# Altium schematic sheet GPS_WS_NEO7M.SchDoc(GPS_WS_NEO7M)
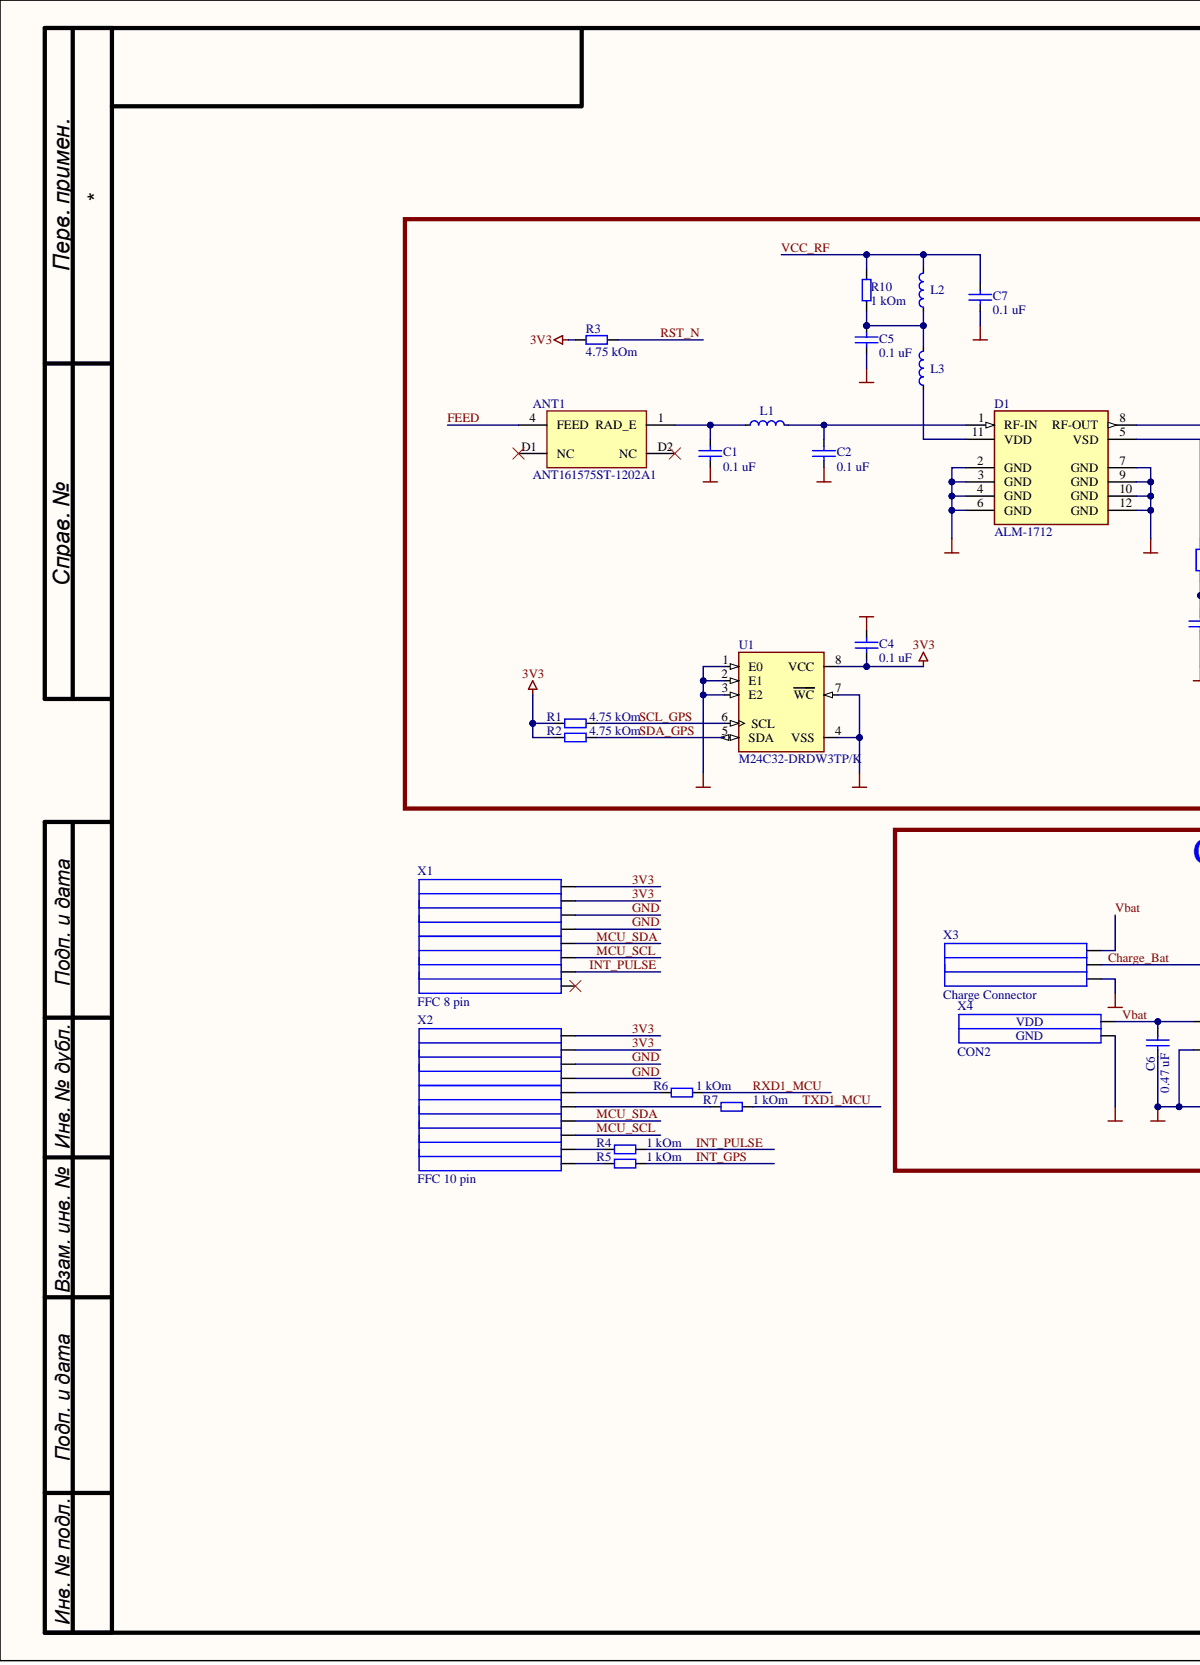
[diagram p0: GPS_WS_NEO7M.SchDoc, left]
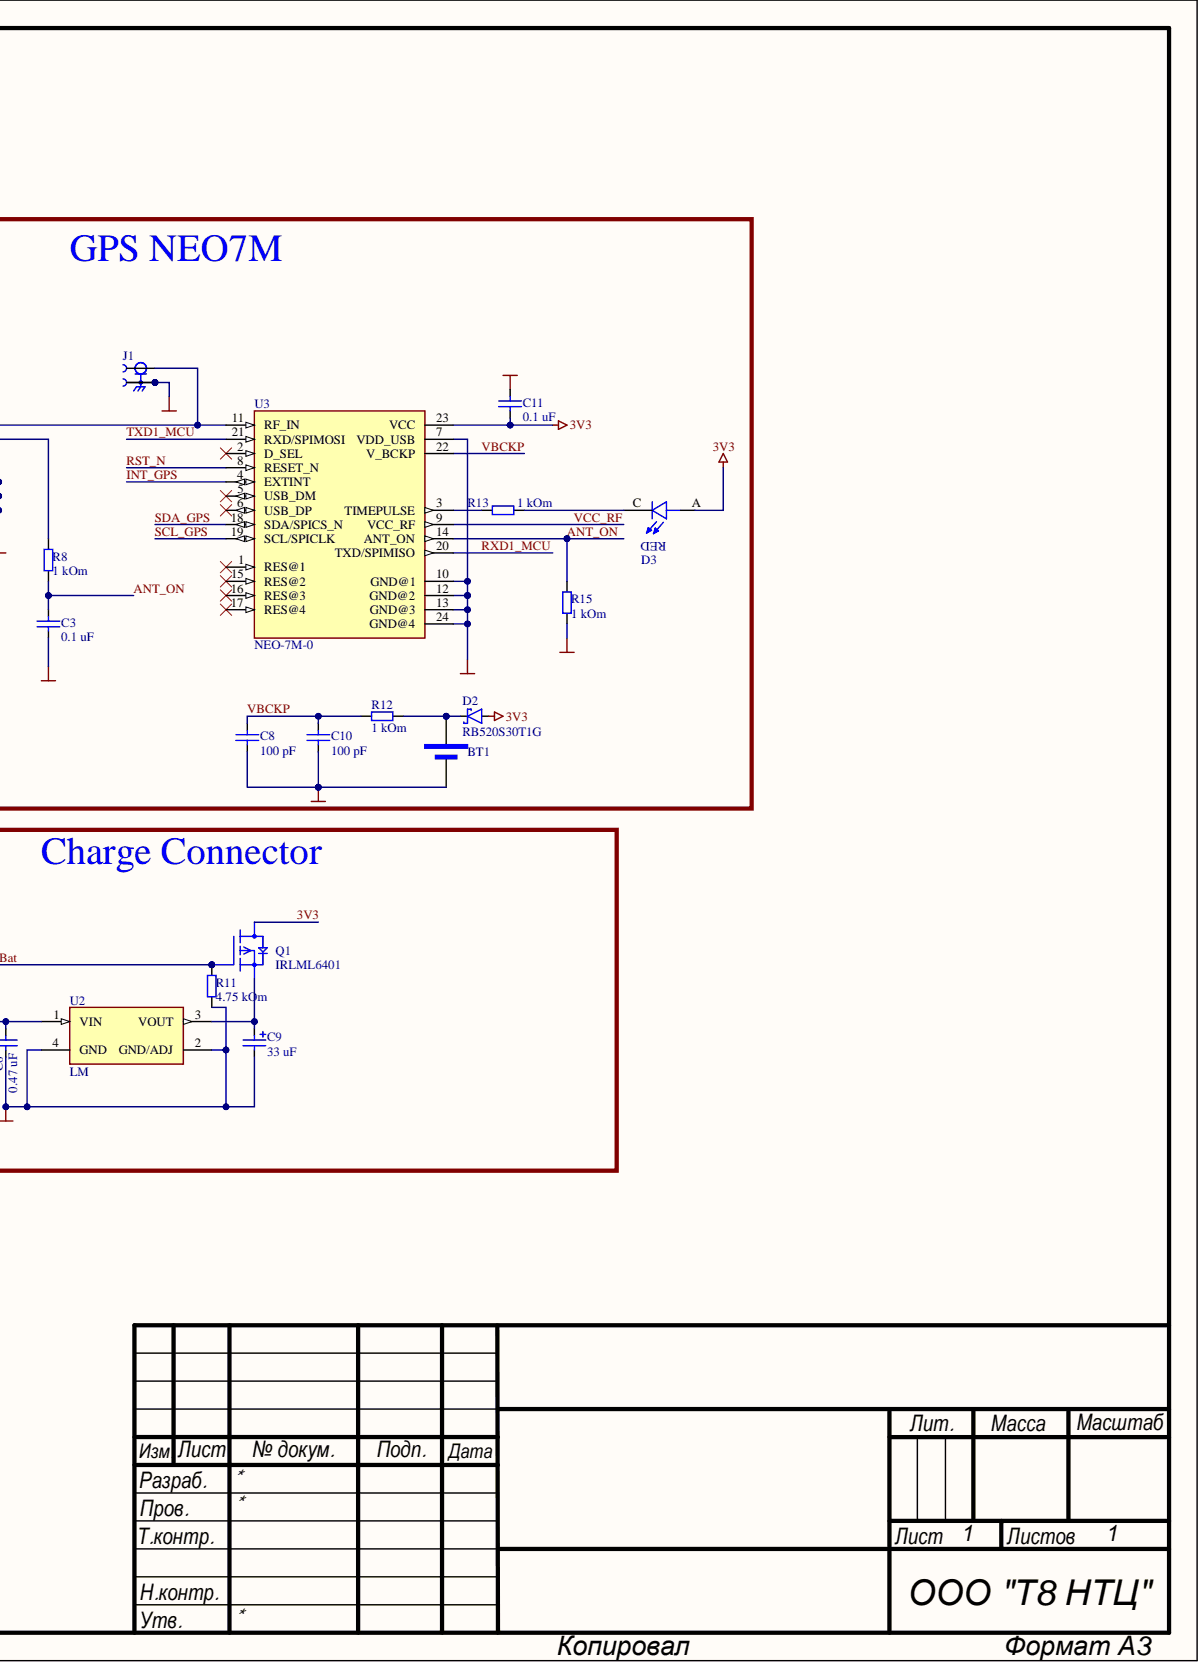
[diagram p1: GPS_WS_NEO7M.SchDoc, right]

## Parts
ANT1  ANT161575ST-1202A1  [ANT161575ST1202A1]
BT1  ML414H_IV01E  [SEIKO_ML414H_IV01E]  (value not drawn)
C1  value 0.1 uF  [CAPC1005X55N]  (hidden comment: STD_CAP)
C2  value 0.1 uF  [CAPC1005X55N]  (hidden comment: STD_CAP)
C3  value 0.1 uF  [CAPC1005X55N]  (hidden comment: STD_CAP)
C4  value 0.1 uF  [CAPC1005X55N]  (hidden comment: STD_CAP)
C5  value 0.1 uF  [CAPC1005X55N]  (hidden comment: STD_CAP)
C6  value 0.47 uF  [CAPC1608X90N]  (hidden comment: STD_CAP)
C7  value 0.1 uF  [CAPC1608X90N]  (hidden comment: STD_CAP)
C8  value 100 pF  [CAPC1005X55N]  (hidden comment: STD_CAP)
C9  value 33 uF  [CAPMP3216X180N]  (hidden comment: STD_CAP_POL)
C10  value 100 pF  [CAPC1005X55N]  (hidden comment: STD_CAP)
C11  value 0.1 uF  [CAPC1005X55N]  (hidden comment: STD_CAP)
D1  ALM-1712  [ALM-1712]
D2  RB520S30T1G  [SOD523]
D3  7012X1  [LED_7012X1]  (value not drawn)
J1  CONUFL001-SMD  [LINX_CONUFL001-SMD]  (value not drawn)
L1  STD_IND  [RESC1005X40N]  (value not drawn)
L2  STD_IND  [RESC1005X40N]  (value not drawn)
L3  STD_IND  [RESC1005X40N]  (value not drawn)
Q1  IRLML6401  [SOT23]
R1  value 4.75 kOm  [RESC1005X40N]  (hidden comment: 2904)
R2  value 4.75 kOm  [RESC1005X40N]  (hidden comment: 2904)
R3  value 4.75 kOm  [RESC1005X40N]  (hidden comment: 2904)
R4  value 1 kOm  [RESC1005X40N]  (hidden comment: 2839)
R5  value 1 kOm  [RESC1005X40N]  (hidden comment: 2839)
R6  value 1 kOm  [RESC1005X40N]  (hidden comment: 2839)
R7  value 1 kOm  [RESC1005X40N]  (hidden comment: 2839)
R8  value 1 kOm  [RESC1005X40N]  (hidden comment: 2839)
R10  value 1 kOm  [RESC1005X40N]  (hidden comment: 2839)
R11  value 4.75 kOm  [RESC1005X40N]  (hidden comment: 2904)
R12  value 1 kOm  [RESC1005X40N]  (hidden comment: 2839)
R13  value 1 kOm  [RESC1005X40N]  (hidden comment: 2839)
R15  value 1 kOm  [RESC1005X40N]  (hidden comment: 2839)
U1  M24C32-DRDW3TP/K  [SOP65P640X120-8N]
U2  LM  [SOT229P700X180-4N]
U3  NEO-7M-0  [XCVR_NEO-7M-0]
X1  FFC 8 pin  [Charge Connector]
X2  FFC 10 pin  [SAMTEC_ZF5S-10-01-T-WT]
X3  Charge Connector  [POGO PINS]
X4  CON2  [CON2]

## Nets
3V3: C4.2 C11.2 D2.2 D3.A Q1.3 R1.1 R2.1 R3.2 U1.8(VCC) U3.23(VCC) X1.1 X1.2 X2.1 X2.2
ANT_ON: C3.1 R8.2 R15.1 U3.14(ANT_ON)
Charge_Bat: Q1.1 R11.2 X3.2
FEED: ANT1.4(FEED)
GND: BT1.2 C1.2 C2.2 C3.2 C4.1 C5.2 C6.2 C7.2 C8.2 C9.2 C10.2 C11.1 D1.2(GND) D1.3(GND) D1.4(GND) D1.6(GND) D1.7(GND) D1.9(GND) D1.10(GND) D1.12(GND) J1.2 J1.3 R11.1 R15.2 U1.1(E0) U1.2(E1) U1.3(E2) U1.4(VSS) U1.7(WC) U2.2(GND/ADJ) U2.4(GND) U3.7(VDD_USB) U3.10(GND@1) U3.12(GND@2) U3.13(GND@3) U3.24(GND@4) X1.3 X1.4 X2.3 X2.4 X3.3 X4.2
INT_GPS: R5.2 U3.4(EXTINT)
INT_PULSE: R4.2 X1.7
MCU_SCL: X1.6 X2.8
MCU_SDA: X1.5 X2.7
RST_N: R3.1 U3.8(RESET_N)
RXD1_MCU: R6.1 U3.20(TXD/SPIMISO)
SCL_GPS: R1.2 U1.6(SCL) U3.19(SCL/SPICLK)
SDA_GPS: R2.2 U1.5(SDA) U3.18(SDA/SPICS_N)
TXD1_MCU: R7.2 U3.21(RXD/SPIMOSI)
Vbat: C6.1 U2.1(VIN) X3.1 X4.1
VBCKP: C8.1 C10.1 R12.2 U3.22(V_BCKP)
VCC_RF: C7.1 L2.2 R10.1 U3.9(VCC_RF)
NetANT1_1: ANT1.1(RAD_E) C1.1 L1.1
NetBT1_1: BT1.1 D2.1 R12.1
NetC2_1: C2.1 D1.1(RF-IN) L1.2
NetC5_1: C5.1 L2.1 L3.2 R10.2
NetC9_1: C9.1 Q1.2 U2.3(VOUT)
NetD1_5: D1.5(VSD) R8.1
NetD1_8: D1.8(RF-OUT) J1.1 U3.11(RF_IN)
NetD1_11: D1.11(VDD) L3.1
NetD3_C: D3.C R13.1
NetR4_1: R4.1 X2.9
NetR5_1: R5.1 X2.10
NetR6_2: R6.2 X2.5
NetR7_1: R7.1 X2.6
NetR13_2: R13.2 U3.3(TIMEPULSE)

Not connected: ANT1 D1(NC) D2(NC); U3 1(RES@1) 2(D_SEL) 5(USB_DM) 6(USB_DP) 15(RES@2) 16(RES@3) 17(RES@4); X1 8

## Library data of the parts
ANT1 = ANT161575ST-1202A1: Manufacturer: AMOTECH; ManufacturerPartnumber: AMAN1003030ST01; BOM: GPS Antenna    AMAN1003030ST01 AMOTECH; Human_Readable: GPS Antenna; Component Kind: Standard; Footprint_Description: Custom; Library Name: SCH_Wearing_sensor.SchLib
BT1 = ML414H_IV01E: Coin, 4.8mm 3 V Lithium Battery Rechargeable (Secondary) 1mAh; Manufacturer: Seiko Instruments; ManufacturerPartnumber: ML414H IV01E; BOM: Перезаряжаемая батарейка    ML414H IV01E Seiko Instruments; Human_Readable: Перезаряжаемая батарейка; Availability: In Stock; Check_prices: https://www.snapeda.com/parts/ML414H%20IV01E/Seiko+Instruments/view-part/?ref=eda; Component Kind: Standard; Footprint_Description: SOT-89 Seiko; MF: Seiko Instruments; MP: ML414H IV01E; Package: SOT-89 Seiko; Price: None; Purchase-URL: https://pricing.snapeda.com/search/part/ML414H IV01E/?ref=eda; Library Name: SCH_Wearing_sensor.SchLib
C1-C5 C11 = 5571: Manufacturer: muRata; ManufacturerPartnumber: GRM155R71H104KE14D; AuthorCreation_Comp: altium_lib_sch_p; AuthorModification_Comp: anosova; BOM: Конденсатор 0402 0.1 uF ±10% 50V X7R    GRM155R71H104KE14D muRata; Comp_Class_Name: Capacitors Chip SMD 0402; Comp_Class_Number: 200003; Comp_Description: Capacitors 0402 0.10 uF ±10% 50V X7R; Comp_ID: 200003-430; Database Table Name: Comp_All; Dielectric: X7R; Human_Readable: Конденсатор 0402 0.1 uF ±10% 50V X7R; Lib_Description: Capacitor; Precision: 10%; Temp_Range: -55°C to +125°C; Voltage_Range: 50V; Component Kind: Standard; ComponentLink1Description: MANUFACTURER_URL; ComponentLink1URL: https://www.murata.com/~/media/webrenewal/support/library/catalog/products/capacitor/mlcc/c02e.ashx; Footprint_Description: Chip Capacitor EIA 0402. METRIC 1005, 2-Leads, Body 1,00x0,5mm, IPC Medium Density; HelpURL: \\corp1\RNDlibrary\_Library\Altium_lib\Datasheet\muRata\Chip_Monolithic_Ceramic_Capacitors\c02e.pdf; Library Name: Altium_lib.SVNDbLib; Number_Base: 5571; TimeCreation_Comp: 05.04.2018 11:21:57; TimeModification_Comp: 18.11.2021 13:11:41; Verified: N
C6 = 3938: Manufacturer: muRata; ManufacturerPartnumber: GRM188R71A474KA61D; BOM: Конденсатор 0603 0.47 uF ±10% 10V X7R    GRM188R71A474KA61D muRata; Comp_Class_Name: Capacitors Chip SMD 0603; Comp_Class_Number: 200004; Comp_Description: Capacitors 0603 0.47 uF ±10% 10V X7R; Comp_ID: 200004-0111; Database Table Name: Comp_All; Dielectric: X7R; Human_Readable: Конденсатор 0603 0.47 uF ±10% 10V X7R; Lib_Description: Capacitor; Precision: ±10%; Temp_Range: -55 to 125°C; Voltage_Range: 10V; Component Kind: Standard; ComponentLink1Description: MANUFACTURER_URL; ComponentLink1URL: http://www.murata.com/products/catalog/pdf/c02e.pdf; Footprint_Description: Chip Capacitor EIA 0603. METRIC 1608, 2-Leads, Body 1,6x0,8mm, IPC Medium Density; HelpURL: \\corp1\RNDlibrary\_Library\Altium_lib\Datasheet\muRata\Chip_Monolithic_Ceramic_Capacitors\Chip_Monolithic_Ceramic_Capacitors.pdf; Library Name: Altium_lib.SVNDbLib; Number_Base: 3938; TimeModification_Comp: 06.12.2011 14:46:17
C7 = 6202: Manufacturer: TDK; ManufacturerPartnumber: C1608X7R1H104K; AuthorCreation_Comp: anosova; BOM: Capacitors 0603 0.1 uF ±10% 50V X7R    C1608X7R1H104K TDK; Comp_Class_Name: Capacitors Chip SMD 0603; Comp_Class_Number: 200004; Comp_Description: Capacitors 0603 0.1 uF ±10% 50V X7R; Comp_ID: 200004-122; Database Table Name: Comp_All; Dielectric: X7R; Lib_Description: Capacitor; Precision: ± 10%; Temp_Range: -55°C to +125°C; Voltage_Range: 50 V; Component Kind: Standard; ComponentLink1Description: MANUFACTURER_URL; ComponentLink1URL: https://media.digikey.com/pdf/Data%20Sheets/TDK%20PDFs/C_Series_GeneralAppl_B11.pdf; Footprint_Description: Chip Capacitor EIA 0603. METRIC 1608, 2-Leads, Body 1,60x0,8mm, IPC Medium Density; HelpURL: \\corp1\RNDlibrary\_Library\Altium_lib\Datasheet\TDK\Ceramic_Capacitors_C-Series\cseries.pdf; Human_Readable: Capacitors 0603 0.1 uF ±10% 50V X7R; Library Name: Altium_lib.SVNDbLib; Number_Base: 6202; TimeCreation_Comp: 29.10.2021 11:15:01; TimeModification_Comp: 29.10.2021 11:29:43; Verified: N; AuthorModification_Comp: anosova
C8 C10 = 3764: Manufacturer: muRata; ManufacturerPartnumber: GRM1555C1H101JA01D; AuthorModification_Comp: anosova; BOM: Конденсатор 0402 100 pF ±5% 50V  C0G (NP0)    GRM1555C1H101JA01D muRata; Comp_Class_Name: Capacitors Chip SMD 0402; Comp_Class_Number: 200003; Comp_Description: Capacitors 0402 100 pF ±5% 50V  C0G (NP0); Comp_ID: 200003-0363; Database Table Name: Comp_All; Dielectric: C0G (NP0); Human_Readable: Конденсатор 0402 100 pF ±5% 50V  C0G (NP0); Lib_Description: Capacitor; Precision: ±5%; Temp_Range: 25 to 125°C; Voltage_Range: 50V; Component Kind: Standard; ComponentLink1Description: MANUFACTURER_URL; ComponentLink1URL: http://www.murata.com/products/catalog/pdf/c02e.pdf; Footprint_Description: Chip Capacitor EIA 0402. METRIC 1005, 2-Leads, Body 1,00x0,5mm, IPC Medium Density; HelpURL: \\corp1\RNDlibrary\_Library\Altium_lib\Datasheet\muRata\Chip_Monolithic_Ceramic_Capacitors\Chip_Monolithic_Ceramic_Capacitors.pdf; Library Name: Altium_lib.SVNDbLib; Number_Base: 3764; Price: 0,0599999986588955; TimeModification_Comp: 18.11.2021 12:51:58
C9 = 4456: Manufacturer: Kemet; ManufacturerPartnumber: T491A336K010AT; BOM: Конденсатор танталовый корпус A  METRIC 3216-18   33 uF 10% 10V    T491A336K010AT Kemet; Comp_Class_Name: Capacitors Tantal; Comp_Class_Number: 2010; Comp_Description: Tantal Capacitor Case A  METRIC 3216-18   33 uF 10% 10V; Comp_ID: 2010-0363; Database Table Name: Comp_All; Human_Readable: Конденсатор танталовый корпус A  METRIC 3216-18   33 uF 10% 10V; Lib_Description: Polar Capacitor; Precision: 10%; Temp_Range: -55°C to +125°C; Voltage_Range: 10V; Component Kind: Standard; ComponentLink1Description: MANUFACTURER_URL; ComponentLink1URL: http://www.kemet.com/kemet/web/homepage/kechome.nsf/weben/9F2DE33590D9247E8525788D0059B1E1/$file/KEM_TC101_STD.pdf; Footprint_Description: Tantal Capacitor,Case A, METRIC 3216-18. 2-Leads, Body 3.2x1.6mm, IPC Medium Density; HelpURL: \\corp1\RNDlibrary\_Library\Altium_lib\Datasheet\KEMET\T491\KEM_TC101_STD.pdf; Library Name: Altium_lib.SVNDbLib; Number_Base: 4456; TimeModification_Comp: 08.12.2011 13:13:16
D1 = ALM-1712: Sot223/Pkg 1-Amp 3.3-Volt Low Drop Out Voltage Regulatator; MF: Advanced Monolithic Systems; MP: AMS1117-3.3; Availability: Not in stock; Check_prices: https://www.snapeda.com/parts/AMS1117-3.3/Advanced+Monolithic+Systems/view-part/?ref=eda; Component Kind: Standard; Package: SOT-223 Seeed Technology; Price: None; SnapEDA_Link: https://www.snapeda.com/parts/AMS1117-3.3/Advanced+Monolithic+Systems/view-part/?ref=snap; Library Name: SCH_Wearing_sensor.SchLib
D2 = RB520S30T1G: Diode Schottky 30 V 200mA (DC) Surface Mount SOD-523; Manufacturer: NXP Semiconductors; ManufacturerPartnumber: 1PS79SB70; BOM: Diode Schotky    1PS79SB70 NXP Semiconductors; Human_Readable: Diode Schotky; Component Kind: Standard; Footprint_Description: SOD523; MP: 1PS79SB70; Package: None; Price: None; Library Name: SCH_Wearing_sensor.SchLib
D3 = 7012X1: Red - LED Indication - Discrete 1.7V 0805 (2012 Metric); MF: VCC; Availability: In Stock; Check_prices: https://www.snapeda.com/parts/7012X1/Visual+Communications/view-part/?ref=eda; Component Kind: Standard; Library Name: 7012X1.SchLib; MP: 7012X1; Package: 0805 Visual Communications; Price: None; Purchase-URL: https://pricing.snapeda.com/search/part/7012X1/?ref=eda; SnapEDA_Link: https://www.snapeda.com/parts/7012X1/Visual+Communications/view-part/?ref=snap
J1 = CONUFL001-SMD: Manufacturer: TE Connectivity; ManufacturerPartnumber: 1909763-1; BOM: UMCC разъем    1909763-1 TE Connectivity; Human_Readable: UMCC разъем; Availability: In Stock; Check_prices: https://www.snapeda.com/parts/CONUFL001-SMD/Linx/view-part/5842340/?ref=eda; Component Kind: Standard; Footprint_Description: UMCC; Package: None; Price: None; SnapEDA_Link: https://www.snapeda.com/parts/CONUFL001-SMD/Linx/view-part/5842340/?ref=snap; Library Name: SCH_Wearing_sensor.SchLib
L1-L3 = 5343: Manufacturer: muRata; ManufacturerPartnumber: LQW15AN24NG00; AuthorCreation_Comp: altium_lib_sch_p; BOM: RF Inductor wire wound 0402 Size    LQW15AN24NG00 muRata; Comp_Class_Name: Inductances Chip; Comp_Class_Number: 13010000; Comp_Description: RF Inductor wire wound 0402 Size; Comp_ID: 13010000-030; Current_Range: 280 mA; Database Table Name: Comp_All; Precision: ±2%; Temp_Range: -55°C to +125°C; Value: 24 nH; Component Kind: Standard; ComponentLink1Description: MANUFACTURER_URL; HelpURL: \\Corp1\RNDlibrary\_Library\Altium_lib\Datasheet\muRata\Chip_Inductors\Chip_Inductors.o05e.pdf; Human_Readable: RF Inductor wire wound 0402 Size; Lib_Description: RF Inductor wire wound 0402 Size; Library Name: Altium_lib.SVNDbLib; Number_Base: 5343; Price: 0,239999994635582; TimeCreation_Comp: 10.06.2015 18:13:48; TimeModification_Comp: 10.06.2015 18:37:11; Verified: N; AuthorModification_Comp: altium_lib_sch_p
Q1 = IRLML6401: P-Channel 12V 4.3A (Ta) 1.3W (Ta) Surface Mount Micro3â„¢/SOT-23; Manufacturer: International Rectifier; BOM: P-MOS    IRLML6401 International Rectifier; Human_Readable: P-MOS; Availability: Unavailable; Check_prices: https://www.snapeda.com/parts/IRLML6401/International%20Rectifier/view-part/557178/?ref=eda; Component Kind: Standard; Footprint_Description: SOT-23 International Rectifier; ManufacturerPartnumber: IRLML6401; Price: None; SnapEDA_Link: https://www.snapeda.com/parts/IRLML6401/International%20Rectifier/view-part/557178/?ref=snap; Library Name: SCH_Wearing_sensor.SchLib
R1-R3 R11 = 2904: Manufacturer: Yageo; ManufacturerPartnumber: RC0402FR-074K75L; BOM: Резистор 0402 1% E96 4,75 кОм    RC0402FR-074K75L Yageo; Comp_Class_Name: Resistors Chip SMD 0402 1% E96; Comp_Class_Number: 11010201; Comp_Description: Resistor 0402 1% E96 4.75 kOm; Comp_ID: 11010201-354; Database Table Name: Comp_All; Human_Readable: Резистор 0402 1% E96 4,75 кОм; Lib_Description: Resistor; Power_Range: 0.0625W; Precision: 1%; Temp_Range: -55 °C to +155 °C; Component Kind: Standard; ComponentLink1Description: MANUFACTURER_URL; ComponentLink1URL: http://www.yageo.com/documents/recent/PYu-RC0402_51_RoHS_L_6.pdf; Footprint_Description: Chip Resistor EIA 0402. METRIC 1005, 2-Leads, Body 1,00x0,5mm, IPC Medium Density; HelpURL: \\corp1\RNDlibrary\_Library\Altium_lib\Datasheet\Yageo\RC0402\PYu-RC0402_51_RoHS_L_6.pdf; Library Name: Altium_lib.SVNDbLib; Number_Base: 2904; Price: 0,00600000005215406; TimeModification_Comp: 06.12.2011 13:34:56
R4-R8 R10 R12 R13 R15 = 2839: Manufacturer: Yageo; ManufacturerPartnumber: RC0402FR-071K00L; BOM: Резистор 0402 1% E96 1 кОм    RC0402FR-071K00L Yageo; Comp_Class_Name: Resistors Chip SMD 0402 1% E96; Comp_Class_Number: 11010201; Comp_Description: Resistor 0402 1% E96 1 kOm; Comp_ID: 11010201-289; Database Table Name: Comp_All; Human_Readable: Резистор 0402 1% E96 1 кОм; Lib_Description: Resistor; Power_Range: 0.0625W; Precision: 1%; Temp_Range: -55 °C to +155 °C; Component Kind: Standard; ComponentLink1Description: MANUFACTURER_URL; ComponentLink1URL: http://www.yageo.com/documents/recent/PYu-RC0402_51_RoHS_L_6.pdf; Footprint_Description: Chip Resistor EIA 0402. METRIC 1005, 2-Leads, Body 1,00x0,5mm, IPC Medium Density; HelpURL: \\corp1\RNDlibrary\_Library\Altium_lib\Datasheet\Yageo\RC0402\PYu-RC0402_51_RoHS_L_6.pdf; Library Name: Altium_lib.SVNDbLib; Number_Base: 2839; Price: 0,00600000005215406; TimeModification_Comp: 06.12.2011 13:34:56
U1 = M24C32-DRDW3TP/K: M24C02-F Series 2 Kb (256 x 8) 5.5 V Serial IÂ²C bus EEPROMs - TSSOP-8; Manufacturer: STMicroelectronics; BOM: EEPROM    M24C32-DRDW3TP/K STMicroelectronics; Human_Readable: EEPROM; Availability: Unavailable; Component Kind: Standard; Footprint_Description: TSSOP8; ManufacturerPartnumber: M24C32-DRDW3TP/K; Package: None; Price: None; Library Name: SCH_Wearing_sensor.SchLib
U2 = LM: Sot223/Pkg 1-Amp 3.3-Volt Low Drop Out Voltage Regulatator; Manufacturer: Texas Instruments; ManufacturerPartnumber: LM3940IMP-3.3; BOM: LDO    LM3940IMP-3.3 Texas Instruments; Human_Readable: LDO; MF: Advanced Monolithic Systems; MP: AMS1117-3.3; Availability: Not in stock; Check_prices: https://www.snapeda.com/parts/AMS1117-3.3/Advanced+Monolithic+Systems/view-part/?ref=eda; Component Kind: Standard; Footprint_Description: SOT-223 Seeed Technology; Price: None; SnapEDA_Link: https://www.snapeda.com/parts/AMS1117-3.3/Advanced+Monolithic+Systems/view-part/?ref=snap; Library Name: SCH_Wearing_sensor.SchLib
U3 = NEO-7M-0: NEO-7 RF Receiver GLONASS, GNSS, GPS 1.575GHz -161dBm; Manufacturer: U-Blox America; BOM: NEO-7M-0 U-Blox America; Availability: Unavailable; Component Kind: Standard; Footprint_Description: SMD-24 u-blox; ManufacturerPartnumber: NEO-7M-0; MF: U-Blox America; MP: NEO-7M-0; Package: SMD-24 u-blox; SnapEDA_Link: https://www.snapeda.com/parts/NEO-7M-0/U-Blox%20America%20Inc./view-part/48598/?ref=snap; Library Name: SCH_Wearing_sensor.SchLib
X1 = FFC 8 pin: FFC connector 8 pin; Component Kind: Standard; Library Name: SCH_Wearing_sensor.SchLib
X2 = FFC 10 pin: FFC connector 8 pin; Component Kind: Standard; Library Name: SCH_Wearing_sensor.SchLib
X3 = Charge Connector: Выводы под плату зарядки; Component Kind: Standard; Library Name: SCH_Wearing_sensor.SchLib
X4 = CON2: Коннектор двухконтактный; Manufacturer: Robiton; ManufacturerPartnumber: LP401230; BOM: Аккумулятор литий ионный    LP401230 Robiton; Human_Readable: Аккумулятор литий ионный; Component Kind: Standard; Footprint_Description: Custom; Library Name: SCH_Wearing_sensor.SchLib
